# Altium schematic sheet devices.SchDoc(devices)
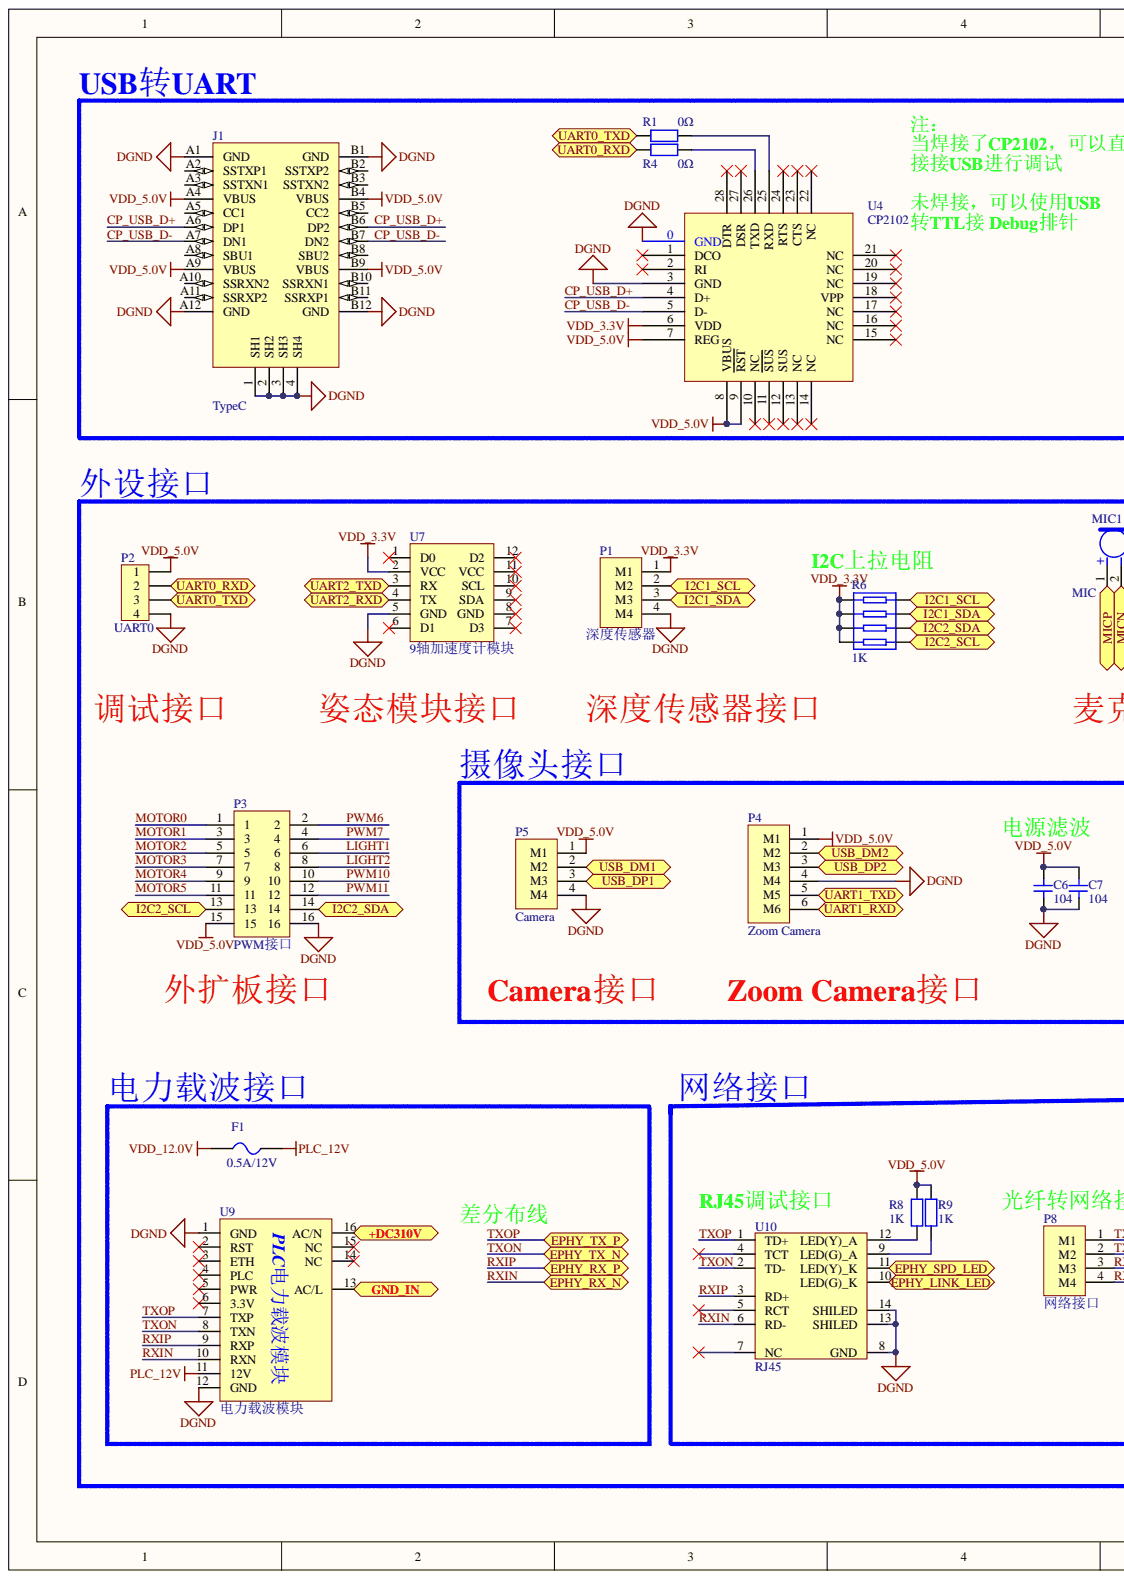
[diagram p0: devices.SchDoc, left]
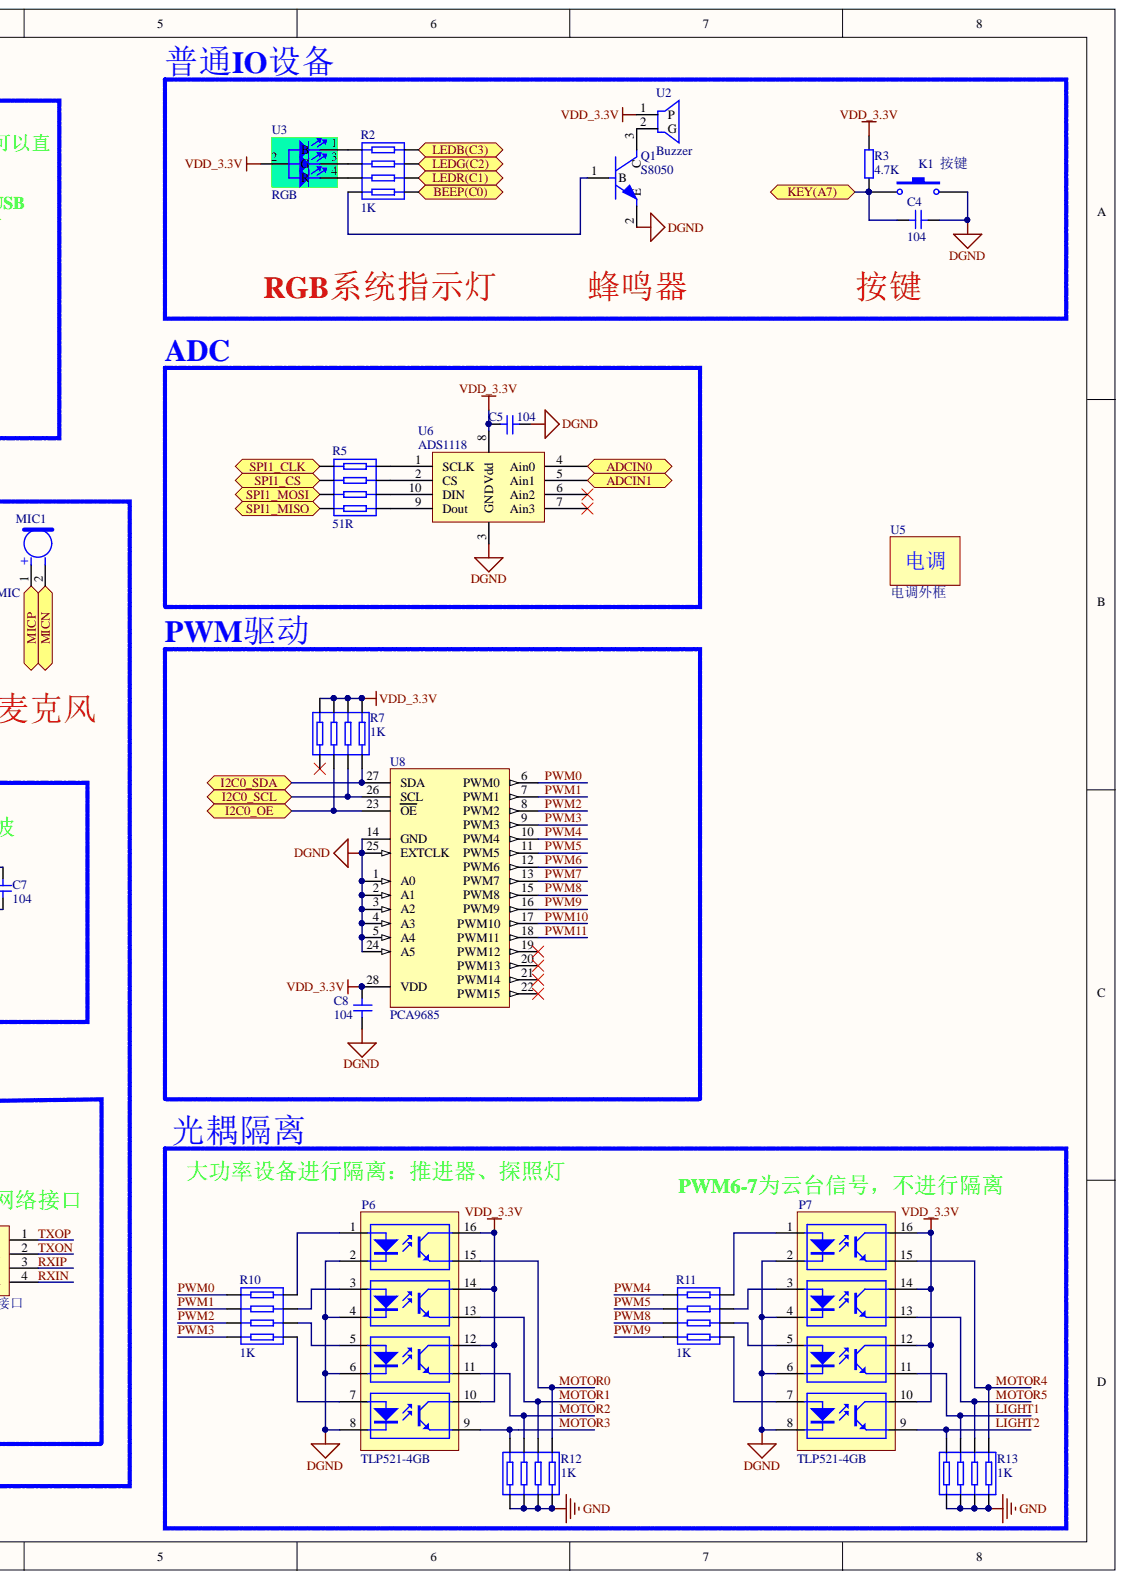
[diagram p1: devices.SchDoc, right]

## Parts
C4  104  [0805C]
C5  104  [0805C]
C6  104  [0805C]
C7  104  [0805C]
C8  104  [0805C]
F1  0.5A/12V  [1206F]
J1  TypeC  [TYPE-C 16P]
K1  按键  [贴片按键]
MIC1  MIC  [MIC]
P1  深度传感器  [MX1.25S-4P]
P2  UART0  [Header 4(排母)]
P3  PWM接口  [HDR2X8/2.54-SMD]
P4  Zoom Camera  [PH2.0WS-6P]
P5  Camera  [PH2.0WS-4P]
P6  TLP521-4GB  [SOP16-W2.54_M]
P7  TLP521-4GB  [SOP16-W2.54_M]
P8  网络接口  [MX1.25S-4P]
Q1  S8050  [SOT-23]
R1  0Ω  [0805R]
R2  1K  [res-4]
R3  4.7K  [0805R]
R4  0Ω  [0805R]
R5  51R  [res-4]
R6  1K  [res-4]
R7  1K  [res-4]
R8  1K  [0805R]
R9  1K  [0805R]
R10  1K  [res-4]
R11  1K  [res-4]
R12  1K  [res-4]
R13  1K  [res-4]
U2  Buzzer  [MLT-9032]
U3  RGB  [RGB-3528]
U4  CP2102  [QFN-28]
U5  电调外框  [电调外框]
U6  ADS1118  [VSSOP-10]
U7  9轴加速度计模块  [9轴模块(简易版)]
U8  PCA9685  [TSSOP-28]
U9  电力载波模块  [电力载波模块6410（贴片排母）]
U10  RJ45  [RJ45]

## Ports
+DC310V, ADCIN0, ADCIN1, BEEP(C0), EPHY_LINK_LED, EPHY_RX_N, EPHY_RX_P, EPHY_SPD_LED, EPHY_TX_N, EPHY_TX_P, GND_IN, I2C0_OE, I2C0_SCL, I2C0_SDA, I2C1_SCL, I2C1_SDA, I2C2_SCL, I2C2_SDA, KEY(A7), LEDB(C3), LEDG(C2), LEDR(C1), MICN, MICP, SPI1_CLK, SPI1_CS, SPI1_MISO, SPI1_MOSI, UART0_RXD, UART0_TXD, UART1_RXD, UART1_TXD, UART2_RXD, UART2_TXD, USB_DM1, USB_DM2, USB_DP1, USB_DP2

## Nets
DGND: C4.1 C5.2 C6.2 C7.2 C8.1 J1.1(SH1) J1.2(SH2) J1.3(SH3) J1.4(SH4) J1.A1(GND) J1.A12(GND) J1.B1(GND) J1.B12(GND) K1.2 P1.4(M4) P2.4 P3.16 P4.4(M4) P5.4(M4) P6.2 P6.4 P6.6 P6.8 P7.2 P7.4 P7.6 P7.8 Q1.2 U4.0(GND) U4.3(GND) U6.3(GND) U7.5(GND) U8.1(A0) U8.2(A1) U8.3(A2) U8.4(A3) U8.5(A4) U8.14(GND) U8.24(A5) U8.25(EXTCLK) U9.1(GND) U9.12(GND) U10.8(GND) U10.13(SHILED) U10.14(SHILED)
GND: R12.1 R12.2 R12.3 R12.4 R13.1 R13.2 R13.3 R13.4
PLC_12V: F1.2 U9.11(12V)
VDD_3.3V: C5.1 C8.2 P1.1(M1) P6.10 P6.12 P6.14 P6.16 P7.10 P7.12 P7.14 P7.16 R3.2 R6.1 R6.2 R6.3 R6.4 R7.5 R7.6 R7.7 R7.8 U2.1 U3.2 U4.6(VDD) U6.8(Vdd) U7.2(VCC) U8.28(VDD)
VDD_5.0V: C6.1 C7.1 J1.A4(VBUS) J1.A9(VBUS) J1.B4(VBUS) J1.B9(VBUS) P2.1 P3.15 P4.1(M1) P5.1(M1) R8.1 R9.1 U4.7(REG) U4.8(VBUS) U4.9(RST)
VDD_12.0V: F1.1
+DC310V: U9.16(AC/N) ; port +DC310V
ADCIN0: U6.4(Ain0) ; port ADCIN0
ADCIN1: U6.5(Ain1) ; port ADCIN1
BEEP(C0): R2.5 ; port BEEP(C0)
CP_USB_D+: J1.A6(DP1) J1.B6(DP2) U4.4(D+)
CP_USB_D-: J1.A7(DN1) J1.B7(DN2) U4.5(D-)
EPHY_LINK_LED: U10.10(LED(G)_K) ; port EPHY_LINK_LED
EPHY_SPD_LED: U10.11(LED(Y)_K) ; port EPHY_SPD_LED
GND_IN: U9.13(AC/L) ; port GND_IN
I2C0_OE: R7.2 U8.23(OE) ; port I2C0_OE
I2C0_SCL: R7.3 U8.26(SCL) ; port I2C0_SCL
I2C0_SDA: R7.4 U8.27(SDA) ; port I2C0_SDA
I2C1_SCL: P1.2(M2) R6.8 ; port I2C1_SCL
I2C1_SDA: P1.3(M3) R6.7 ; port I2C1_SDA
I2C2_SCL: P3.13 R6.5 ; port I2C2_SCL
I2C2_SDA: P3.14 R6.6 ; port I2C2_SDA
KEY(A7): C4.2 K1.1 R3.1 ; port KEY(A7)
LEDB(C3): R2.8 ; port LEDB(C3)
LEDG(C2): R2.7 ; port LEDG(C2)
LEDR(C1): R2.6 ; port LEDR(C1)
LIGHT1: P3.6 P7.11 R13.7
LIGHT2: P3.8 P7.9 R13.8
MICN: MIC1.2 ; port MICN
MICP: MIC1.1 ; port MICP
MOTOR0: P3.1 P6.15 R12.5
MOTOR1: P3.3 P6.13 R12.6
MOTOR2: P3.5 P6.11 R12.7
MOTOR3: P3.7 P6.9 R12.8
MOTOR4: P3.9 P7.15 R13.5
MOTOR5: P3.11 P7.13 R13.6
PWM0: R10.1 U8.6(PWM0)
PWM1: R10.2 U8.7(PWM1)
PWM2: R10.3 U8.8(PWM2)
PWM3: R10.4 U8.9(PWM3)
PWM4: R11.1 U8.10(PWM4)
PWM5: R11.2 U8.11(PWM5)
PWM6: P3.2 U8.12(PWM6)
PWM7: P3.4 U8.13(PWM7)
PWM8: R11.3 U8.15(PWM8)
PWM9: R11.4 U8.16(PWM9)
PWM10: P3.10 U8.17(PWM10)
PWM11: P3.12 U8.18(PWM11)
RXIN: P8.4(M4) U9.10(RXN) U10.6(RD-) ; port EPHY_RX_N
RXIP: P8.3(M3) U9.9(RXP) U10.3(RD+) ; port EPHY_RX_P
SPI1_CLK: R5.1 ; port SPI1_CLK
SPI1_CS: R5.2 ; port SPI1_CS
SPI1_MISO: R5.4 ; port SPI1_MISO
SPI1_MOSI: R5.3 ; port SPI1_MOSI
TXON: P8.2(M2) U9.8(TXN) U10.2(TD-) ; port EPHY_TX_N
TXOP: P8.1(M1) U9.7(TXP) U10.1(TD+) ; port EPHY_TX_P
UART0_RXD: P2.2 R4.2 ; port UART0_RXD
UART0_TXD: P2.3 R1.2 ; port UART0_TXD
UART1_RXD: P4.6(M6) ; port UART1_RXD
UART1_TXD: P4.5(M5) ; port UART1_TXD
UART2_RXD: U7.4(TX) ; port UART2_RXD
UART2_TXD: U7.3(RX) ; port UART2_TXD
USB_DM1: P5.2(M2) ; port USB_DM1
USB_DM2: P4.2(M2) ; port USB_DM2
USB_DP1: P5.3(M3) ; port USB_DP1
USB_DP2: P4.3(M3) ; port USB_DP2
NetP6_1: P6.1 R10.8
NetP6_3: P6.3 R10.7
NetP6_5: P6.5 R10.6
NetP6_7: P6.7 R10.5
NetP7_1: P7.1 R11.8
NetP7_3: P7.3 R11.7
NetP7_5: P7.5 R11.6
NetP7_7: P7.7 R11.5
NetQ1_1: Q1.1 R2.4
NetQ1_3: Q1.3 U2.2
NetR1_1: R1.1 U4.25(RXD)
NetR2_1: R2.1 U3.1(B)
NetR2_2: R2.2 U3.3(G)
NetR2_3: R2.3 U3.4(R)
NetR4_1: R4.1 U4.26(TXD)
NetR5_5: R5.5 U6.9(Dout)
NetR5_6: R5.6 U6.10(DIN)
NetR5_7: R5.7 U6.2(CS)
NetR5_8: R5.8 U6.1(SCLK)
NetR8_2: R8.2 U10.12(LED(Y)_A)
NetR9_2: R9.2 U10.9(LED(G)_A)

Not connected: J1 A2(SSTXP1) A3(SSTXN1) A5(CC1) A8(SBU1) A10(SSRXN2) A11(SSRXP2) B2(SSTXP2) B3(SSTXN2) B5(CC2) B8(SBU2) B10(SSRXN1) B11(SSRXP1); R7 1; U4 1(DCO) 2(RI) 10(NC) 11(SUS) 12(SUS) 13(NC) 14(NC) 15(NC) 16(NC) 17(NC) 18(VPP) 19(NC) 20(NC) 21(NC) 22(NC) 23(CTS) 24(RTS) 27(DSR) 28(DTR); U6 6(Ain2) 7(Ain3); U7 1(D0) 6(D1) 7(D3) 8(GND) 9(SDA) 10(SCL) 11(VCC) 12(D2); U8 19(PWM12) 20(PWM13) 21(PWM14) 22(PWM15); U9 2(RST) 3(ETH) 4(PLC) 5(PWR) 6(3.3V) 14(NC) 15(NC); U10 4(TCT) 5(RCT) 7(NC)

## Library data of the parts
C4-C8 = Cap1: 无极贴片电容; Component Kind: Standard
  C4: Library Name: 集大电协1.1.0.SchLib
  C5-C8: Library Name: 集大电协1.5.SchLib
F1 = Fuse: 保险丝; Component Kind: Standard; Library Name: 集大电协1.5.SchLib
K1 = 贴片按键: 轻触开关; Component Kind: Standard; Library Name: 集大电协1.1.0.SchLib
P1 P5 P8 = 接线端子-4PIN: 4PIN; Component Kind: Standard; Library Name: 集大电协1.5.SchLib
P2 = Header 4: 排针; Component Kind: Standard; Library Name: 集大电协1.5.SchLib
P3 = Header 2X8: Component Kind: Standard; Library Name: 集大电协1.5.SchLib
P4 = 接线端子-6PIN: 6PIN; Component Kind: Standard; Library Name: 集大电协1.5.SchLib
Q1 = NPN: NPN三极管; Component Kind: Standard; Library Name: 集大电协1.1.2.SchLib
R1 R3 R4 R8 R9 = Res: 电阻; Component Kind: Standard
  R1 R4 R8 R9: Library Name: 集大电协1.5.SchLib
  R3: Library Name: 集大电协1.1.0.SchLib
R2 R5-R7 R10-R13 = Res-4: 排阻; Component Kind: Standard; Library Name: 集大电协1.5.SchLib
U2 = Buzzer: 蜂鸣器; Component Kind: Standard; Library Name: 集大电协1.5.SchLib
U4 = CP2102: USB转串口芯片; Component Kind: Standard; Library Name: 集大电协1.5.SchLib
U5 = 电调: Component Kind: Standard; Library Name: 集大电协1.5.SchLib
U7 = 9轴加速度计: Component Kind: Standard; Library Name: 窝牛器件封装.SchLib
U9 = 电力载波模块6410: Component Kind: Standard; Library Name: 集大电协1.5.SchLib
J1 = TypeC: Component Kind: Standard; Library Name: 集大电协1.5.SchLib
MIC1 = MIC: Component Kind: Standard; Library Name: 集大电协1.5.SchLib
P6 P7 = TLP521-4GB: Component Kind: Standard; Library Name: 集大电协1.5.SchLib
U3 = RGB: Component Kind: Standard; Library Name: 集大电协1.1.2.SchLib
U6 = ADS1118: Component Kind: Standard; Library Name: 集大电协1.5.SchLib
U8 = PCA9685: Component Kind: Standard; Library Name: 集大电协1.5.SchLib
U10 = RJ45: Component Kind: Standard; Library Name: 集大电协1.5.SchLib
